# Altium schematic sheet main_esp.SchDoc(main_esp)
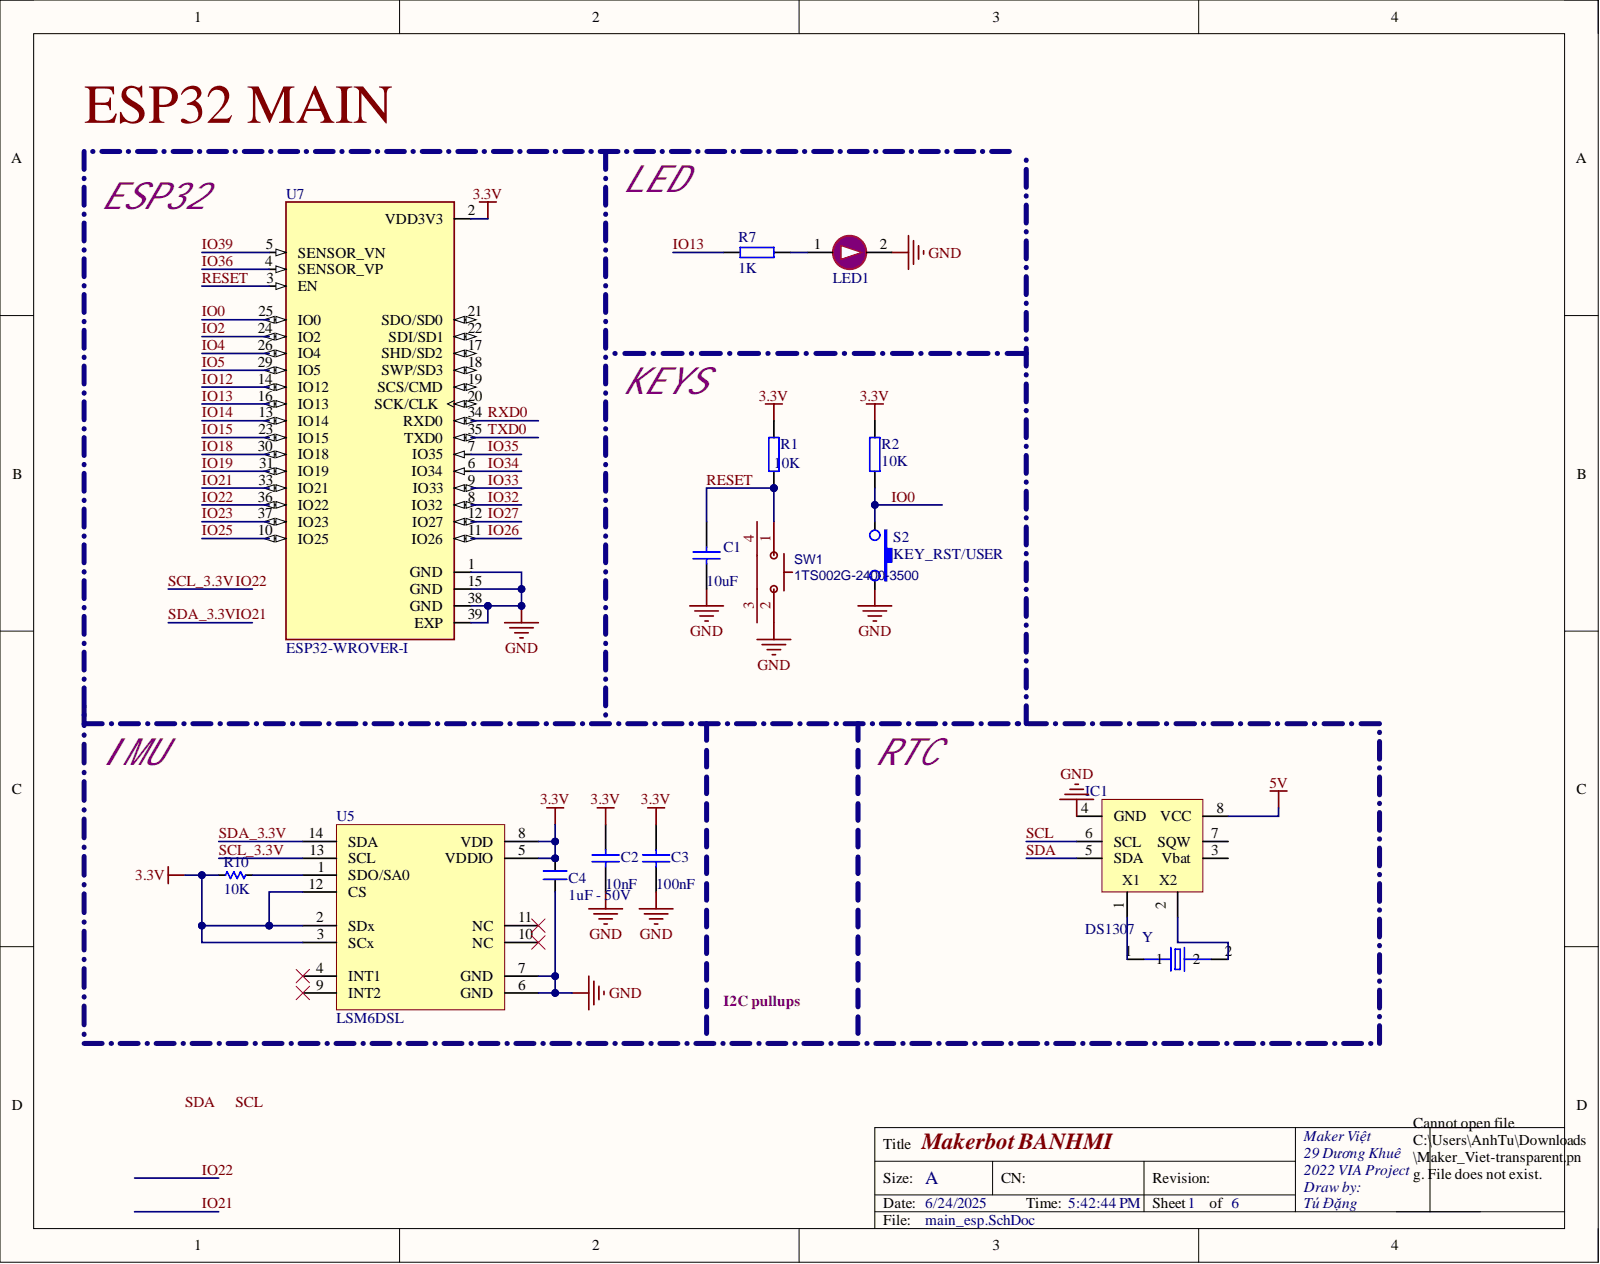
[diagram p0: main_esp.SchDoc]

## Parts
C1  value 10uF  (hidden comment: Cap Semi)
C2  value 10nF  (hidden comment: Cap Semi)
C3  value 100nF  (hidden comment: Cap Semi)
C4  1uF - 50V
IC1  DS1307
LED1  LED3  (value not drawn)
R1  value 10K  (hidden comment: Resistor)
R2  value 10K  (hidden comment: Resistor)
R7  value 1K  (hidden comment: Resistor)
R10  10K
S2  KEY_RST/USER
SW1  1TS002G-2400-3500
U5  LSM6DSL
U7  ESP32-WROVER-I
Y  ABS07AIG-32.768KHZ-7-1-T  (value not drawn)

## Nets
3.3V: C2.2 C3.2 C4.2 R1.2 R2.2 R10.2 U5.2(SDx) U5.3(SCx) U5.5(VDDIO) U5.8(VDD) U5.12(CS) U7.2(VDD3V3)
5V: IC1.8(VCC)
GND: C1.1 C2.1 C3.1 C4.1 IC1.4(GND) LED1.2 S2.2 SW1.2 U5.6(GND) U5.7(GND) U7.1(GND) U7.15(GND) U7.38(GND) U7.39(EXP)
VCC: IC1.8(VCC)
IO0: R2.1 S2.1 U7.25(IO0)
IO2: U7.24(IO2)
IO4: U7.26(IO4)
IO5: U7.29(IO5)
IO12: U7.14(IO12)
IO13: R7.1 U7.16(IO13)
IO14: U7.13(IO14)
IO15: U7.23(IO15)
IO18: U7.30(IO18)
IO19: U7.31(IO19)
IO21: U5.14(SDA) U7.33(IO21)
IO22: U5.13(SCL) U7.36(IO22)
IO23: U7.37(IO23)
IO25: U7.10(IO25)
IO26: U7.11(IO26)
IO27: U7.12(IO27)
IO32: U7.8(IO32)
IO33: U7.9(IO33)
IO34: U7.6(IO34)
IO35: U7.7(IO35)
IO36: U7.4(SENSOR_VP)
IO39: U7.5(SENSOR_VN)
RESET: C1.2 R1.1 SW1.1 U7.3(EN)
RXD0: U7.34(RXD0)
SCL: IC1.6(SCL)
SDA: IC1.5(SDA)
TXD0: U7.35(TXD0)
NetIC1_1: IC1.1(X1) Y.1
NetIC1_2: IC1.2 Y.2
NetLED1_1: LED1.1 R7.2
NetR10_1: R10.1 U5.1(SDO/SA0)

Not connected: IC1 3(Vbat) 7(SQW); SW1 3 4; U5 4(INT1) 9(INT2) 10(NC) 11(NC); U7 17(SHD/SD2) 18(SWP/SD3) 19(SCS/CMD) 20(SCK/CLK) 21(SDO/SD0) 22(SDI/SD1)

## Library data of the parts
C1 = CAP-SM-0603-X5R-10uF: CAP SM 0603 X5R 10uF 10%; Component Kind: Standard; Library Name: electronpowered.SchLib; Supplier 1: Farnell; Supplier 2: Digi-Key; Supplier Part Number 1: 2366833; Supplier Part Number 2: 490-3896-1-ND; Tolerance: ±10%
C2 = CAP-SM-0603-X5R-10uF: CAP SM 0603 X5R 10nF 10%; Component Kind: Standard; Library Name: electronpowered.SchLib; Supplier 1: Farnell; Supplier 2: Digi-Key; Supplier Part Number 1: 2366833; Supplier Part Number 2: 490-3896-1-ND; Tolerance: ±10%
C3 = CAP-SM-0603-X7R-100nF: CAP SM 0603 X7R 100nF 10%; Component Kind: Standard; Library Name: electronpowered.SchLib; Supplier 1: Digi-Key; Supplier 2: Farnell; Supplier Part Number 1: 490-3167-1-ND; Supplier Part Number 2: 1775994RL; Tolerance: ±10%
C4 = C0603X5R105M500NT: CAP CER 1UF 50V X5R 0603; Manufacture: Hottech; Database Table Name: Components; Detailed Value: 1uF - 50V - 0603; PROJECT: KHO; Category: Capacitor; Component Kind: Standard; Mounting type: Surface Mount; Partnumber: C0603X5R105M500NT; Value: 1uF - 50V; Creator: ThangND; Library Name: MKP_lib.DbLib
IC1 = DS1307: Real Timer Serial; Component Kind: Standard; Library Name: My Library.SchLib; Value: DS1307
LED1 = LED0805: LED SMD 0805 RED; Component Kind: Standard; Library Name: My Library.SchLib
R1 R2 = RES-SM-0603-10K: RES SM 0603 10K; Component Kind: Standard; Library Name: electronpowered.SchLib; Supplier 1: Farnell; Supplier 2: Digi-Key; Supplier Part Number 1: 9330399; Supplier Part Number 2: 541-10.0KHCT-ND
R7 = RES-SM-0603-1K: RES SM 0603 1K; Component Kind: Standard; Library Name: electronpowered.SchLib
R10 = RI0603L1002FT: RES SMD 10K OHM 1% 1/10W 0603; Manufacture: Hottech; Database Table Name: Components; Detailed Value: 10K - 1% - 1/10W; PROJECT: KHO; Category: Resistor; Component Kind: Standard; Mounting type: Surface Mount; Partnumber: RI0603L1002FT; Value: 10K; Creator: anhdn; Library Name: MKP_lib.DbLib
S2 = SMT_SW_PTS_820: SMT Tactile ‎Switch PTS 820 Series; Component Kind: Standard; Library Name: NODEMCU_DEVKIT_V1.0.IntLib
SW1 = 1TS002G-2400-3500: BOM_Manufacturer: HYP; Contributor: LCSC; BOM_Manufacturer Part: 1TS002G-2400-3500; BOM_Supplier: LCSC; BOM_Supplier Part: C319378; Component Kind: Standard; Library Name: SW.SCHLIB; spicePre: S; spiceSymbolName: 1TS002G-2400-3500
U5 = LSM6DSL: IMU ACCEL/GYRO I2C/SPI 14LGA; Manufacturer 1: STMicroelectronics; Manufacturer Part Number 1: LSM6DSLTR; Output Type: SPI,I2C; Sensor Type: Accelerometer,Gyroscope,3 Axis,Temperature; Case/Package: LGA; Category: Inertial Measurement Units (IMUs); Component Kind: Standard; ComponentLink1Description: http://www.farnell.com/datasheets/2290668.pdf?src-supplier=Farnell; ComponentLink1URL: http://www.farnell.com/datasheets/2290668.pdf?src-supplier=Farnell; ComponentLink2Description: https://www.mouser.com/ds/2/389/lsm6dsl-973708.pdf?src-supplier=Mouser; ComponentLink2URL: https://www.mouser.com/ds/2/389/lsm6dsl-973708.pdf?src-supplier=Mouser; ComponentLink3Description: http://www.st.com/content/ccc/resource/technical/document/datasheet/ee/23/a0/dc/1d/68/45/52/DM00237456.pdf/files/DM00237456.pdf/jcr:content/translations/en.DM00237456.pdf?src-supplier=Digi-Key; ComponentLink3URL: http://www.st.com/content/ccc/resource/technical/document/datasheet/ee/23/a0/dc/1d/68/45/52/DM00237456.pdf/files/DM00237456.pdf/jcr:content/translations/en.DM00237456.pdf?src-supplier=Digi-Key; ComponentLink4Description: http://www.farnell.com/datasheets/2290668.pdf?src-supplier=Newark; ComponentLink4URL: http://www.farnell.com/datasheets/2290668.pdf?src-supplier=Newark; ComponentLink5Description: http://www.farnell.com/datasheets/2290668.pdf?src-supplier=Element14; ComponentLink5URL: http://www.farnell.com/datasheets/2290668.pdf?src-supplier=Element14; Max Operating Temperature: 85°C; Min Operating Temperature: -40°C; Mount: Surface Mount; Packaging: Tape and Reel; Pricing 1: 1=5.27000, 10=4.73000, 25=4.47200, 100=3.87560, 250=3.67688, 500=3.29924, 1000=3.28125 (USD); RoHS: Yes; RoHS Compliant: Yes; Supplier 1: Digi-Key; Supplier Part Number 1: 497-16705-1-ND; Library Name: ad_via.SchLib
U7 = ESP32-WROVER-I: Bluetooth, WiFi 802.11b/g/n, Bluetooth v4.2 + EDR, Class 1, 2 and 3 Transceiver Module 2.4GHz ~ 2.5GHz Antenna Not Included, I-PEX Surface Mount; MF: Espressif Systems; Availability: Unavailable; Component Kind: Standard; Library Name: SnapEDAsch.SchLib; MP: ESP32-WROVER-I; Package: Module Espressif Systems; Price: None; SnapEDA_Link: https://www.snapeda.com/parts/ESP32-WROVER-I/Espressif%20Systems/view-part/2298808/?ref=snap
Y = ABS07AIG-32.768KHZ-7-1-T: 32.768kHz Â±10ppm Crystal 7pF 80 kOhms 2-SMD, No Lead; MF: Abracon; BuyPart: https://www.snapeda.com/parts/ABS07AIG-32.768KHZ-7-1-T/Abracon%20LLC/view-part/1099811/?ref=eda; Component Kind: Standard; Digi-Key_Part_Number: 535-13354-1-ND; Library Name: ABS07AIG-32.768KHZ-7-1-T.IntLib; MP: ABS07AIG-32.768KHZ-7-1-T; Package: SMD-2 Abracon; SnapEDA_Link: https://www.snapeda.com/parts/ABS07AIG-32.768KHZ-7-1-T/Abracon%20LLC/view-part/1099811/?ref=snap
